# Altium schematic sheet SCH_W-F-FC-HX2X_BASE.SchDoc(SCH_W-F-FC-HX2X_BASE)
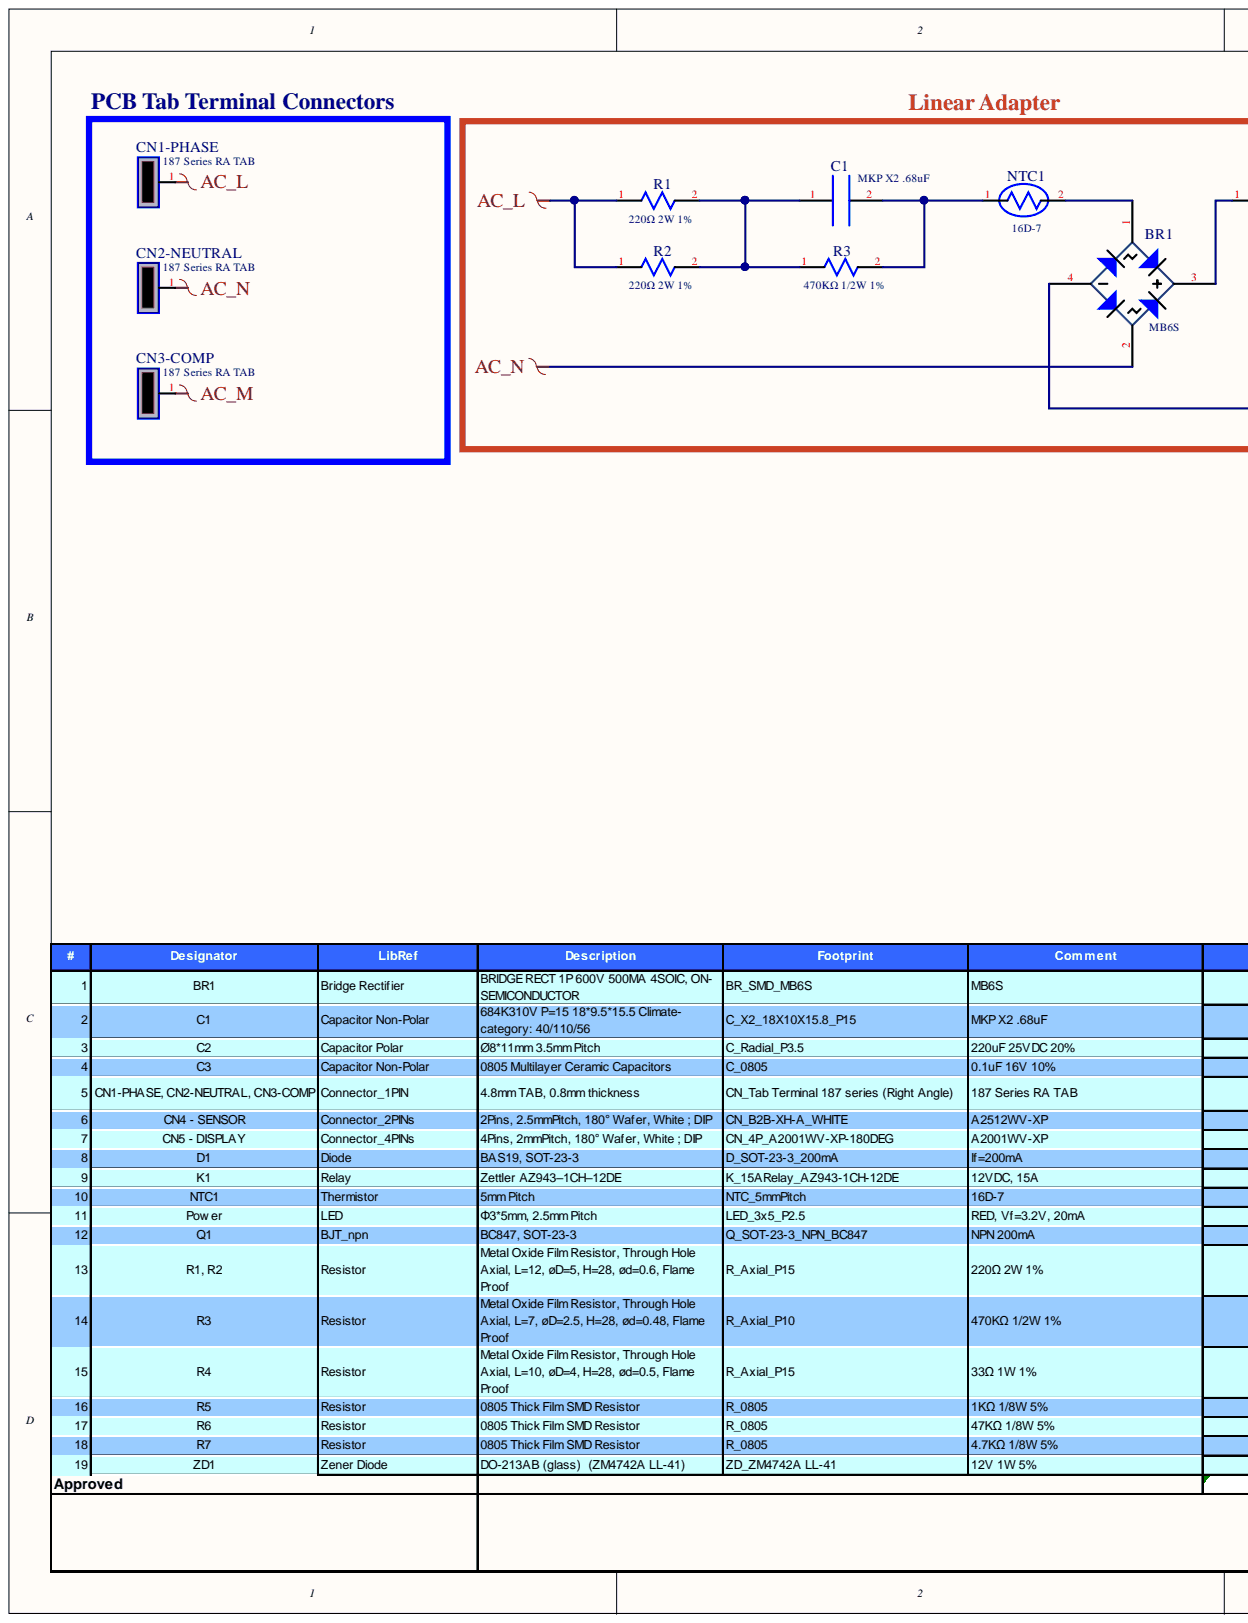
[diagram p0: SCH_W-F-FC-HX2X_BASE.SchDoc, left]
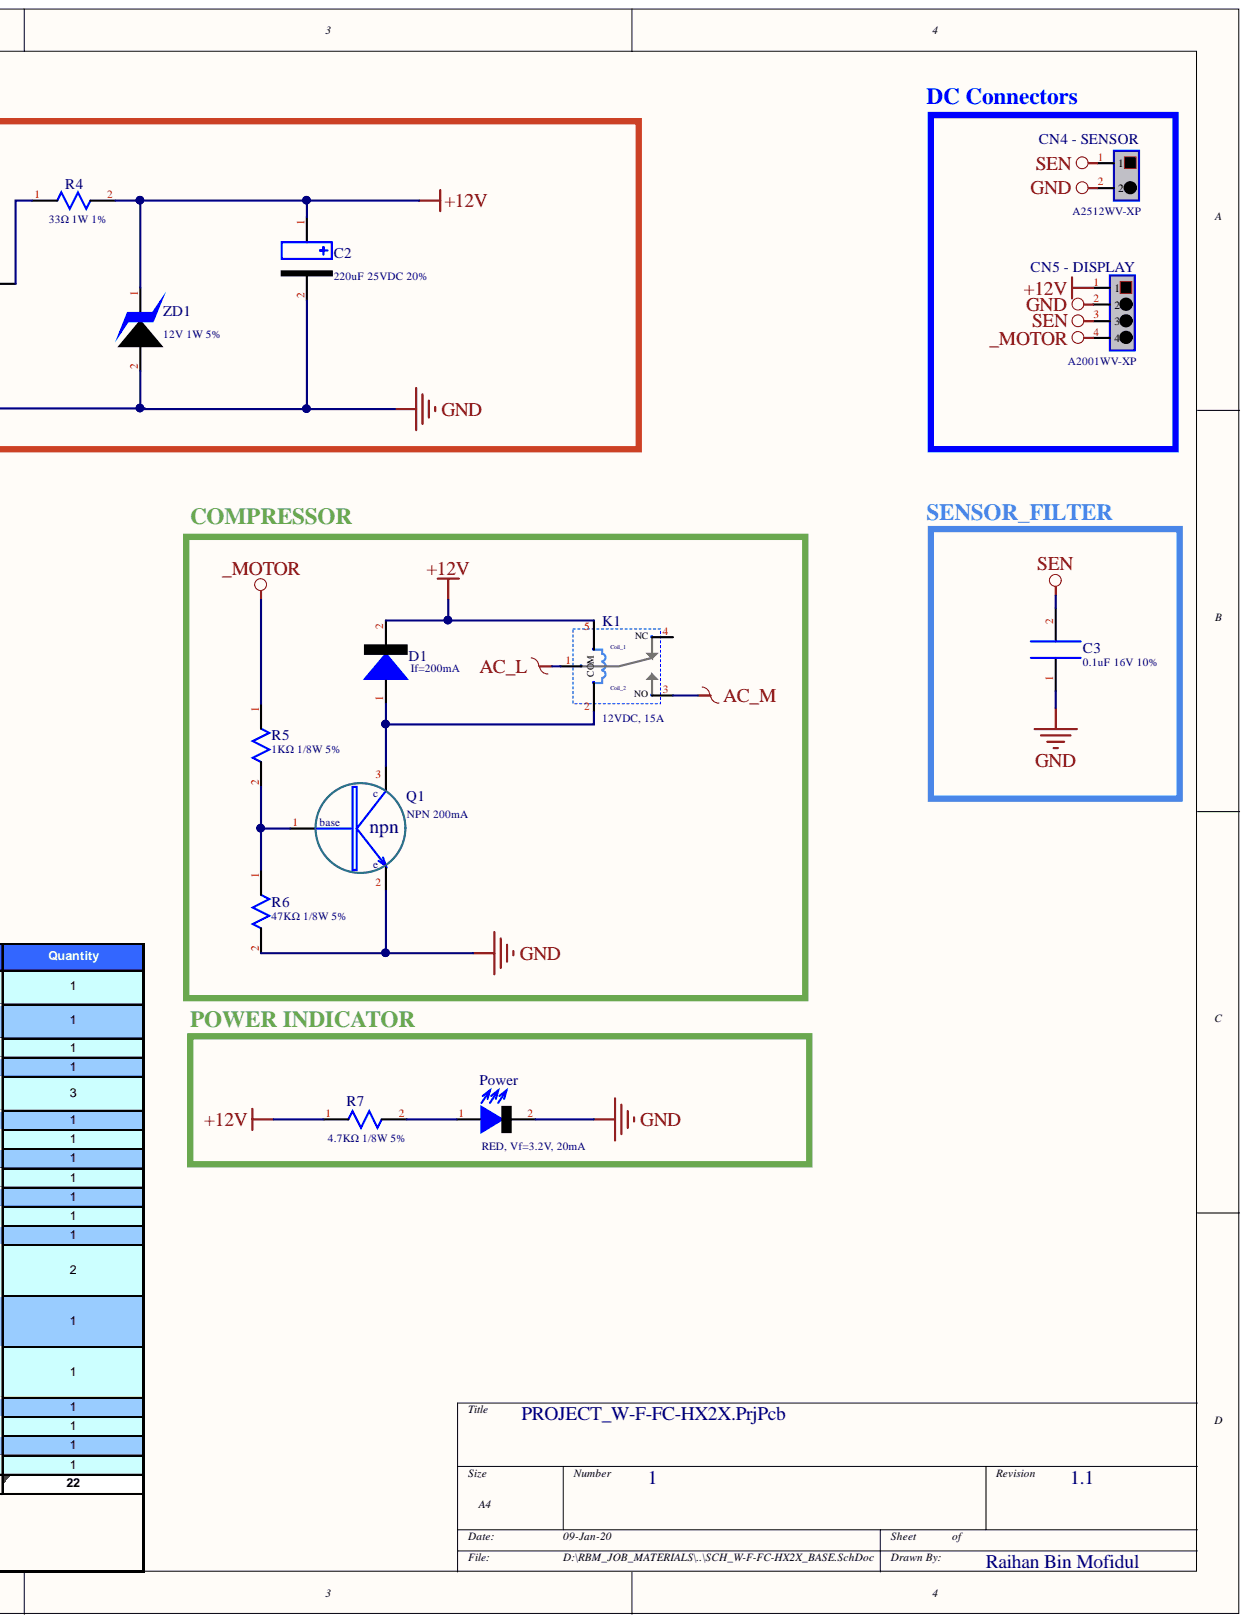
[diagram p1: SCH_W-F-FC-HX2X_BASE.SchDoc, right]

## Parts
BR1  MB6S  [BR_SMD_MB6S]
C1  MKP X2 .68uF  [C_X2_18X10X15.8_P15]
C2  220uF 25VDC 20%  [C_Radial_P3.5]
C3  0.1uF 16V 10%  [C_0805]
CN1-PHASE  187 Series RA TAB  [CN_Tab Terminal 187 series (Right Angle)]
CN2-NEUTRAL  187 Series RA TAB  [CN_Tab Terminal 187 series (Right Angle)]
CN3-COMP  187 Series RA TAB  [CN_Tab Terminal 187 series (Right Angle)]
CN4_-_SENSOR  A2512WV-XP  [CN_B2B-XH-A_WHITE]
CN5_-_DISPLAY  A2001WV-XP  [CN_4P_A2001WV-XP-180DEG]
D1  If=200mA  [D_SOT-23-3_200mA]
K1  12VDC, 15A  [K_15ARelay_AZ943-1CH-12DE]
NTC1  16D-7  [NTC_5mmPitch]
Power  RED, Vf=3.2V, 20mA  [LED_3x5_P2.5]
Q1  NPN 200mA  [Q_SOT-23-3_NPN_BC847]
R1  220Ω 2W 1%  [R_Axial_P15]
R2  220Ω 2W 1%  [R_Axial_P15]
R3  470KΩ 1/2W 1%  [R_Axial_P10]
R4  33Ω 1W 1%  [R_Axial_P15]
R5  1KΩ 1/8W 5%  [R_0805]
R6  47KΩ 1/8W 5%  [R_0805]
R7  4.7KΩ 1/8W 5%  [R_0805]
ZD1  12V 1W 5%  [ZD_ZM4742A LL-41]

## Nets
+12V: C2.1 CN5_-_DISPLAY.1 D1.2 K1.5(COM) R4.2 R7.1 ZD1.1
_MOTOR: CN5_-_DISPLAY.4 R5.1
AC_L: CN1-PHASE.1 K1.1 R1.1 R2.1
AC_M: CN3-COMP.1 K1.3(NO)
AC_N: BR1.2 CN2-NEUTRAL.1
GND: BR1.4 C2.2 C3.1 CN4_-_SENSOR.2 CN5_-_DISPLAY.2 Power.2 Q1.2 R6.2 ZD1.2
SEN: C3.2 CN4_-_SENSOR.1 CN5_-_DISPLAY.3
NetBR1_1: BR1.1 NTC1.2
NetBR1_3: BR1.3 R4.1
NetC1_1: C1.1 R1.2 R2.2 R3.1
NetC1_2: C1.2 NTC1.1 R3.2
NetD1_1: D1.1 K1.2 Q1.3
NetPower_1: Power.1 R7.2
NetQ1_1: Q1.1 R5.2 R6.1

Not connected: K1 4(NC)

## Library data of the parts
BR1 = Bridge Rectifier: BRIDGE RECT 1P 600V 500MA 4SOIC, ON-SEMICONDUCTOR; Component Kind: Standard; Library Name: RBM_SCH-LIB_02-SEP-19.SchLib
C1 = Capacitor Non-Polar: 684K310V P=15 18*9.5*15.5 Climate-category: 40/110/56; Component Kind: Standard; Library Name: RBM_SCH-LIB_02-SEP-19.SchLib
C2 = Capacitor Polar: Ø8*11mm 3.5mm Pitch; Component Kind: Standard; Library Name: RBM_SCH-LIB_02-SEP-19.SchLib
C3 = Capacitor Non-Polar: 0805 Multilayer Ceramic Capacitors; Component Kind: Standard; Library Name: RBM_SCH-Lib_16-Apr-19.SchLib
CN1-PHASE CN2-NEUTRAL CN3-COMP = Connector_1PIN: 4.8mm TAB, 0.8mm thickness; Component Kind: Standard; Library Name: RBM_SCH-LIB_02-SEP-19.SchLib
CN4_-_SENSOR = Connector_2PINs: 2Pins, 2.5mmPitch, 180° Wafer, White ; DIP; Component Kind: Standard; Library Name: RBM_SCH-Lib_16-Apr-19.SchLib
CN5_-_DISPLAY = Connector_4PINs: 4Pins, 2mmPitch, 180° Wafer, White ; DIP; Component Kind: Standard; Library Name: RBM_SCH-LIB_02-SEP-19.SchLib
D1 = Diode: BAS19, SOT-23-3; Component Kind: Standard; Library Name: RBM_SCH-LIB_02-SEP-19.SchLib
K1 = Relay: Zettler AZ943–1CH–12DE; Component Kind: Standard; Library Name: RBM_SCH-Lib_16-Apr-19.SchLib
NTC1 = Thermistor: 5mm Pitch; Component Kind: Standard; Library Name: RBM_SCH-LIB_02-SEP-19.SchLib
Power = LED: Φ3*5mm, 2.5mm Pitch; Component Kind: Standard; Library Name: RBM_SCH-LIB_02-SEP-19.SchLib
Q1 = BJT_npn: BC847, SOT-23-3; Component Kind: Standard; Library Name: RBM_SCH-Lib_16-Apr-19.SchLib
R1 R2 = Resistor: Metal Oxide Film Resistor, Through Hole Axial, L=12, øD=5, H=28, ød=0.6, Flame Proof; Component Kind: Standard; Library Name: RBM_SCH-LIB_02-SEP-19.SchLib
R3 = Resistor: Metal Oxide Film Resistor, Through Hole Axial, L=7, øD=2.5, H=28, ød=0.48, Flame Proof; Component Kind: Standard; Library Name: RBM_SCH-LIB_02-SEP-19.SchLib
R4 = Resistor: Metal Oxide Film Resistor, Through Hole Axial, L=10, øD=4, H=28, ød=0.5, Flame Proof; Component Kind: Standard; Library Name: RBM_SCH-LIB_02-SEP-19.SchLib
R5-R7 = Resistor: 0805 Thick Film SMD Resistor; Component Kind: Standard; Library Name: RBM_SCH-Lib_16-Apr-19.SchLib
ZD1 = Zener Diode: DO-213AB (glass)  (ZM4742A LL-41); Component Kind: Standard; Library Name: RBM_SCH-LIB_02-SEP-19.SchLib
